# Altium schematic sheet PERIPHERALS.SchDoc
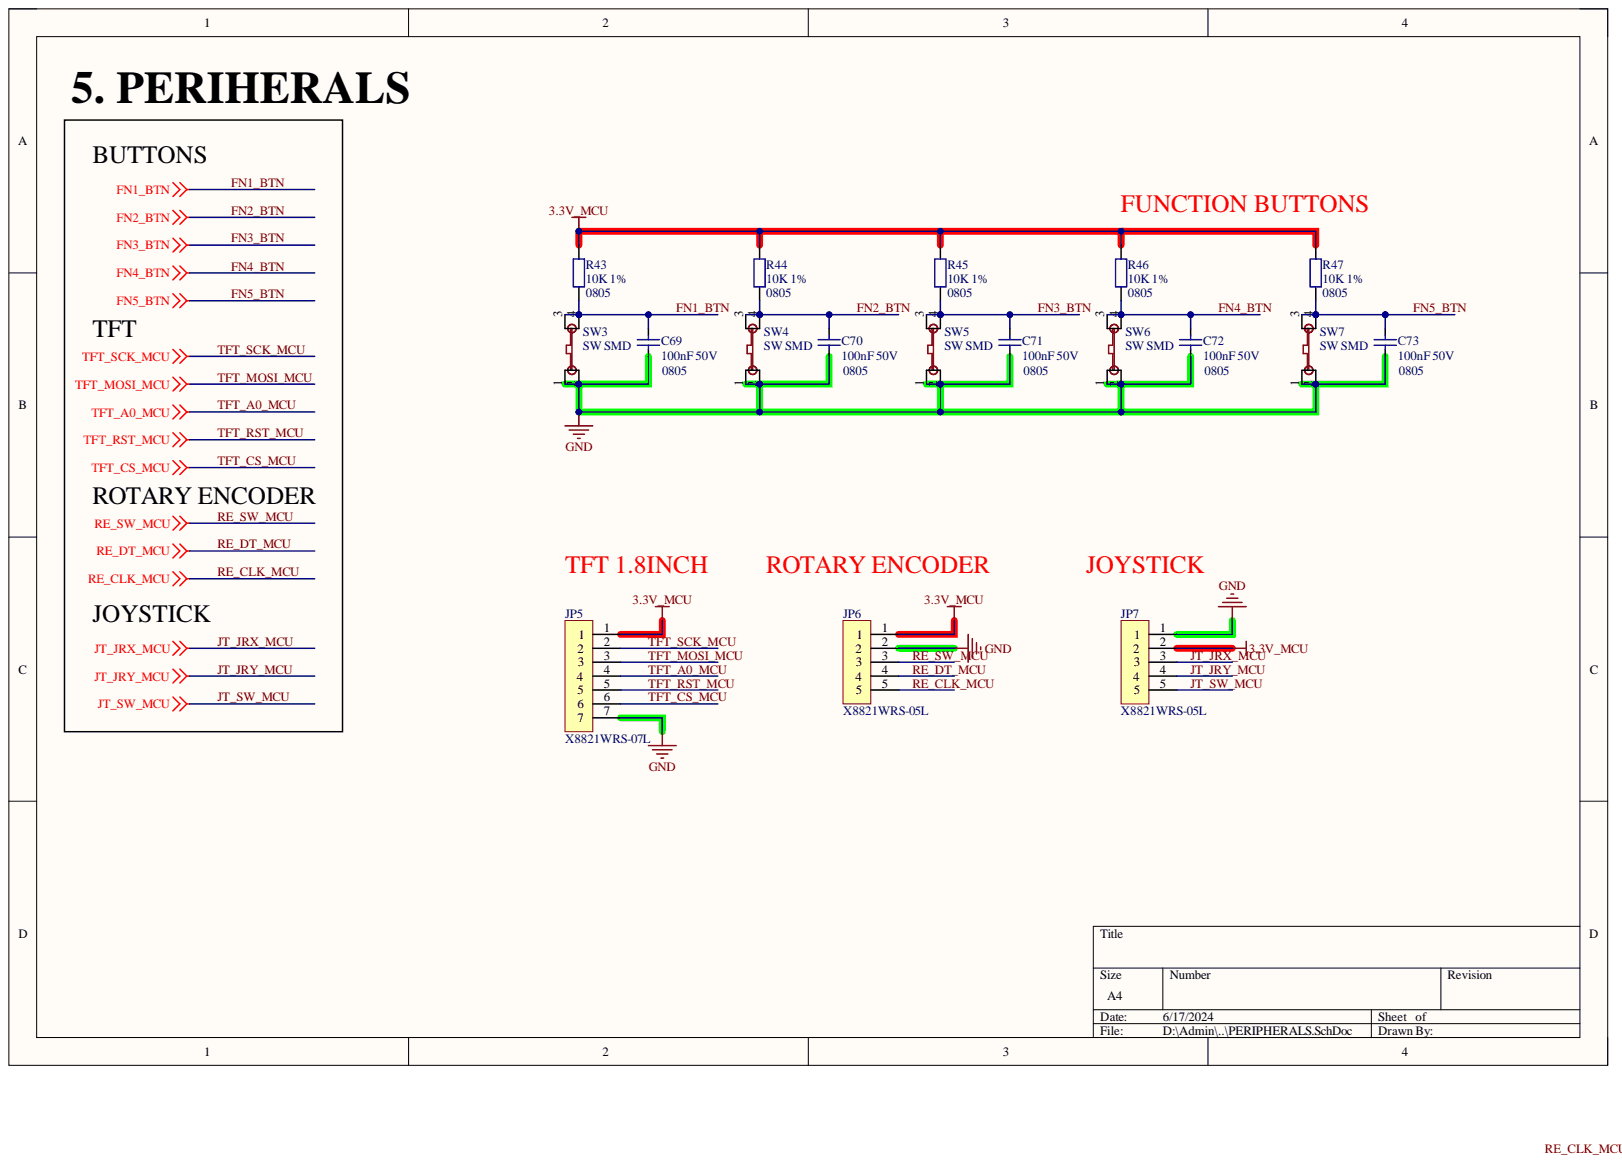
[diagram p0: PERIPHERALS.SchDoc]

## Parts
C69  100nF 50V  Size: 0805  [CAP_SMD_0805]
C70  100nF 50V  Size: 0805  [CAP_SMD_0805]
C71  100nF 50V  Size: 0805  [CAP_SMD_0805]
C72  100nF 50V  Size: 0805  [CAP_SMD_0805]
C73  100nF 50V  Size: 0805  [CAP_SMD_0805]
JP5  X8821WRS-07L  [CONN_X8821WRS-07L-LPSN]
JP6  X8821WRS-05L  [CONN_X8821WRS-05L-LPSN]
JP7  X8821WRS-05L  [CONN_X8821WRS-05L-LPSN]
R43  10K 1%  Size: 0805  [RES_SMD_0805]
R44  10K 1%  Size: 0805  [RES_SMD_0805]
R45  10K 1%  Size: 0805  [RES_SMD_0805]
R46  10K 1%  Size: 0805  [RES_SMD_0805]
R47  10K 1%  Size: 0805  [RES_SMD_0805]
SW3  SW SMD  [SMD_6X6X7]
SW4  SW SMD  [SMD_6X6X7]
SW5  SW SMD  [SMD_6X6X7]
SW6  SW SMD  [SMD_6X6X7]
SW7  SW SMD  [SMD_6X6X7]

## Nets
3.3V_MCU: JP5.1 JP6.1 JP7.2 R43.2 R44.2 R45.2 R46.2 R47.2
GND: C69.1 C70.1 C71.1 C72.1 C73.1 JP5.7 JP6.2 JP7.1 SW3.1 SW3.2 SW4.1 SW4.2 SW5.1 SW5.2 SW6.1 SW6.2 SW7.1 SW7.2
FN1_BTN: C69.2 R43.1 SW3.3 SW3.4
FN2_BTN: C70.2 R44.1 SW4.3 SW4.4
FN3_BTN: C71.2 R45.1 SW5.3 SW5.4
FN4_BTN: C72.2 R46.1 SW6.3 SW6.4
FN5_BTN: C73.2 R47.1 SW7.3 SW7.4
JT_JRX_MCU: JP7.3
JT_JRY_MCU: JP7.4
JT_SW_MCU: JP7.5
RE_CLK_MCU: JP6.5
RE_DT_MCU: JP6.4
RE_SW_MCU: JP6.3
TFT_A0_MCU: JP5.4
TFT_CS_MCU: JP5.6
TFT_MOSI_MCU: JP5.3
TFT_RST_MCU: JP5.5
TFT_SCK_MCU: JP5.2

## Library data of the parts
C69-C73 = C0805_MLCC_100nF_50V: 0.1 µF ±10% 50V Ceramic Capacitor X7R 0805 (2012 Metric); Manufacture Part Number: CC0805KRX7R9BB104; Manufacturer: YAGEO; Component Kind: Standard; Library Name: Capacitors.IntLib; Link: https://www.digikey.cn/en/products/detail/yageo/CC0805KRX7R9BB104/302874; Packaging: Cut Tape (CT); Price: $0.10
JP5 = X8821WRS-07L: 1x7P 7P 1 Tin 2.5mm 7 Brass 3A Surface Mount，Right Angle SMD,P=2.5mm,Surface Mount，Right Angle Wire To Board Connector ROHS; Manufacture Part Number: X8821WRS-07-9TSN; Manufacturer: XKB Connection; Component Kind: Standard; Library Name: Connectors.IntLib; Link: https://www.lcsc.com/product-detail/Wire-To-Board-Connector_XKB-Connection-X8821WRS-07-9TSN_C519020.html; Price: $ 0.1938
JP6 JP7 = X8821WRS-05L: 1x5P 5P XH 1 Tin 2.5mm 5 3A Brass Surface Mount，Right Angle SMD,P=2.5mm,Surface Mount，Right Angle Wire To Board Connector ROHS; Manufacture Part Number: X8821WRS-05-9TSN; Manufacturer: XKB Connection; Component Kind: Standard; Library Name: Connectors.IntLib; Link: https://www.lcsc.com/product-detail/Wire-To-Board-Connector_XKB-Connection-X8821WRS-05-9TSN_C397435.html; Price: $ 0.1678
R43-R47 = R0805_10K: 10 kOhms ±1% 0.125W, 1/8W Chip Resistor 0805 (2012 Metric) Moisture Resistant Thick Film; Manufacture Part Number: RC0805FR-0710KL; Manufacturer: YAGEO; Component Kind: Standard; Library Name: Resistors.IntLib; Link: https://www.digikey.cn/en/products/detail/yageo/RC0805FR-0710KL/727535; Packaging: Cut Tape (CT); Price: $0.10
SW3-SW7 = SW_SMD_6X6X7: 不带 6mm 7mm 50mA Round Button Brick nogging SPST 6mm 8万次 12V SMD Tactile Switches ROHS; Manufacture Part Number: KH-6X6X7H-STM; Manufacturer: Shenzhen Kinghelm Elec; Component Kind: Standard; Library Name: Button.IntLib; Link: https://www.lcsc.com/product-detail/Tactile-Switches_Shenzhen-Kinghelm-Elec-KH-6X6X7H-STM_C2837533.html; Price: $ 0.0185
